# Altium schematic sheet 05_HDMI_O.SchDoc
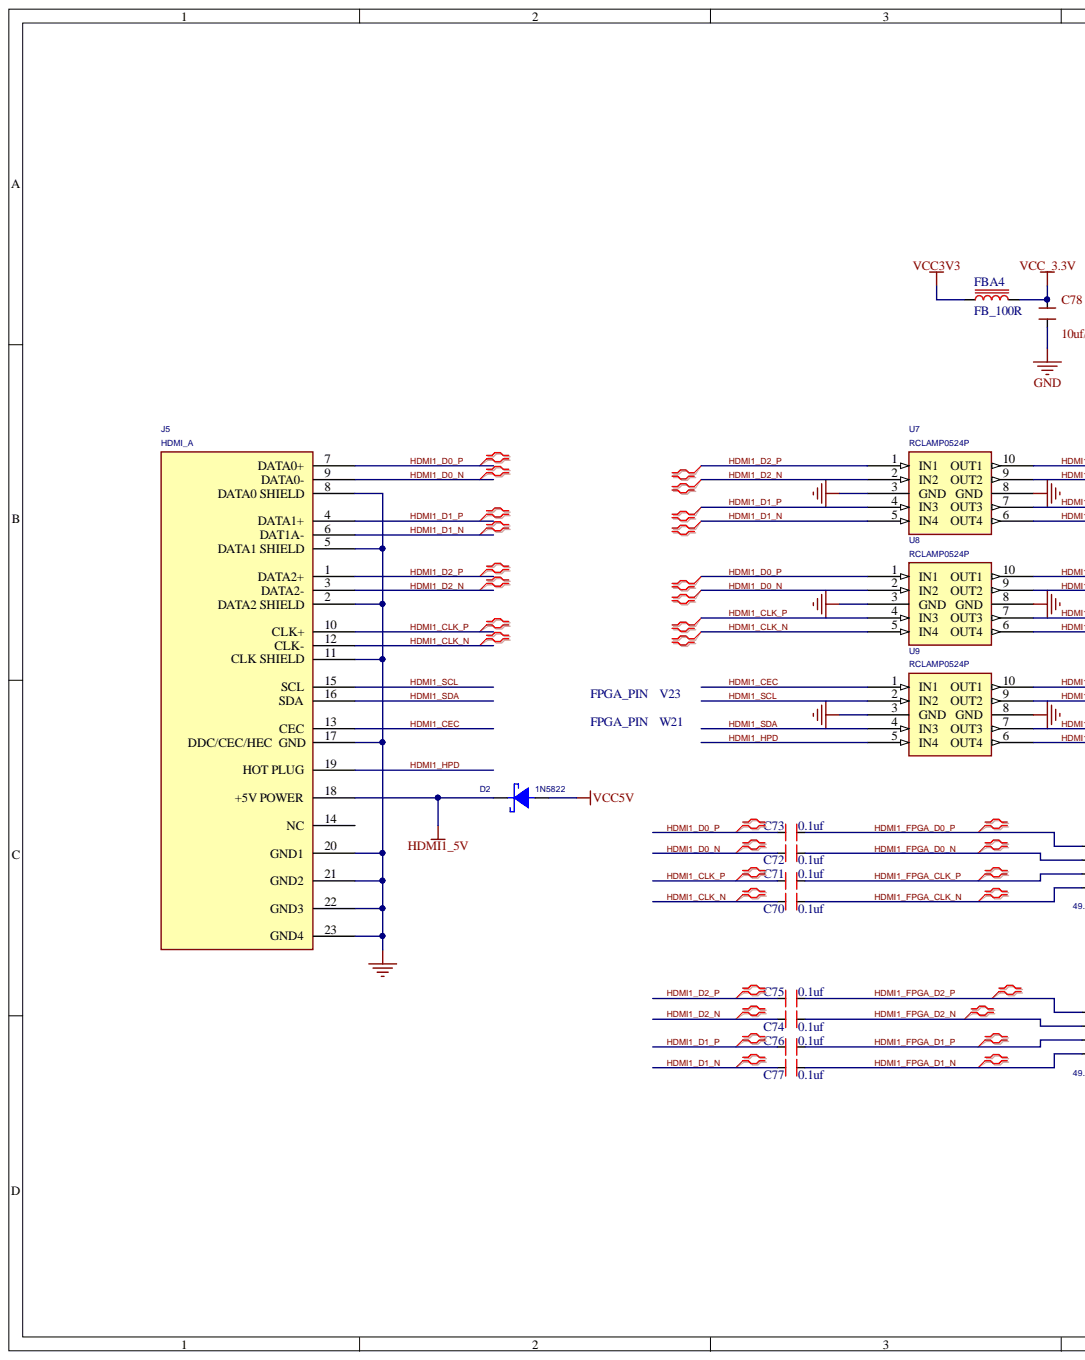
[diagram p0: 05_HDMI_O.SchDoc, left]
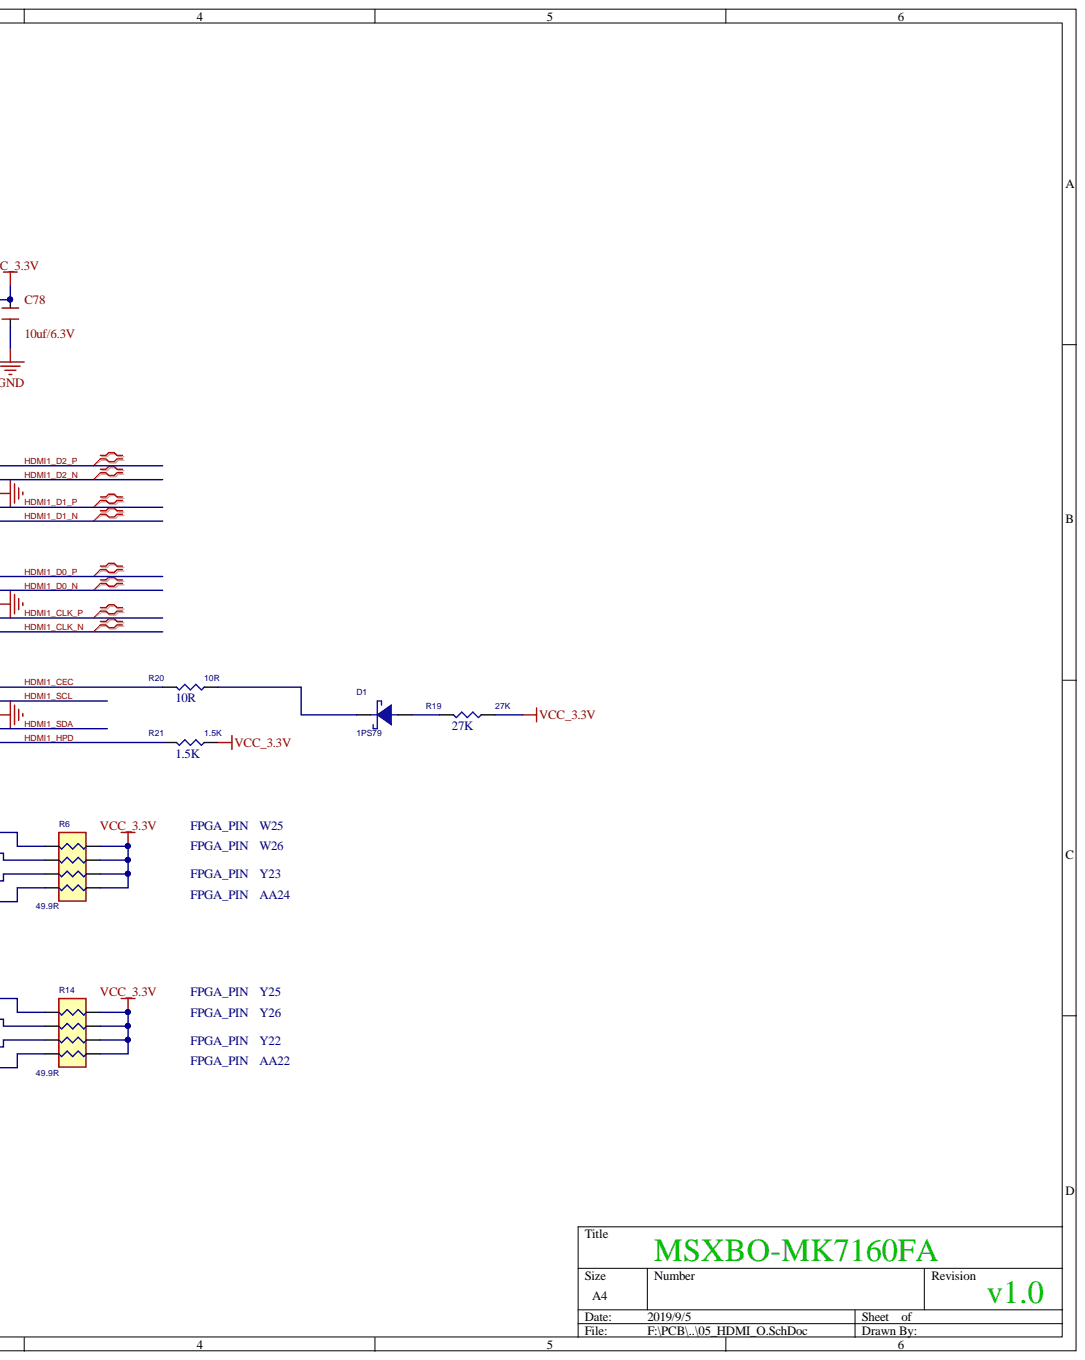
[diagram p1: 05_HDMI_O.SchDoc, right]

## Parts
C70  0.1uf  [C0402]
C71  0.1uf  [C0402]
C72  0.1uf  [C0402]
C73  0.1uf  [C0402]
C74  0.1uf  [C0402]
C75  0.1uf  [C0402]
C76  0.1uf  [C0402]
C77  0.1uf  [C0402]
C78  10uf/6.3V  [CAP0805]
D1  1PS79  [D0603]
D2  1N5822  [SMA_DO_214AC]
FBA4  FB_100R  [CAP0603]
J5  HDMI_A  [HDMI_SMD_A]
R6  49.9R  [RA4_0603]
R14  49.9R  [RA4_0603]
R19  27K  [R0402]
R20  10R  [R0402]
R21  1.5K  [Res0402]
U7  RCLAMP0524P  [SLP2510P8_10]
U8  RCLAMP0524P  [SLP2510P8_10]
U9  RCLAMP0524P  [SLP2510P8_10]

## Nets
GND: C78.2 J5.2(DATA2_SHIELD) J5.5(DATA1_SHIELD) J5.8(DATA0_SHIELD) J5.11(CLK_SHIELD) J5.17(GND) J5.20(GND1) J5.21(GND2) J5.22(GND3) J5.23(GND4) U7.3(GND) U7.8(GND) U8.3(GND) U8.8(GND) U9.3(GND) U9.8(GND)
HDMI1_5V: D2.2 J5.18(+5V_POWER)
VCC3V3: FBA4.1
VCC5V: D2.1
VCC_3.3V: C78.1 FBA4.2 R6.1 R6.2 R6.3 R6.4 R14.1 R14.2 R14.3 R14.4 R19.2 R21.2
HDMI1_CEC: J5.13(CEC) R20.1 U9.1(IN1) U9.10(OUT1)
HDMI1_CLK_N: C70.1 J5.12(CLK-) U8.5(IN4) U8.6(OUT4)
HDMI1_CLK_P: C71.1 J5.10(CLK+) U8.4(IN3) U8.7(OUT3)
HDMI1_D0_N: C72.1 J5.9(DATA0-) U8.2(IN2) U8.9(OUT2)
HDMI1_D0_P: C73.1 J5.7(DATA0+) U8.1(IN1) U8.10(OUT1)
HDMI1_D1_N: C77.1 J5.6(DAT1A-) U7.5(IN4) U7.6(OUT4)
HDMI1_D1_P: C76.1 J5.4(DATA1+) U7.4(IN3) U7.7(OUT3)
HDMI1_D2_N: C74.1 J5.3(DATA2-) U7.2(IN2) U7.9(OUT2)
HDMI1_D2_P: C75.1 J5.1(DATA2+) U7.1(IN1) U7.10(OUT1)
HDMI1_FPGA_CLK_N: C70.2 R6.5
HDMI1_FPGA_CLK_P: C71.2 R6.6
HDMI1_FPGA_D0_N: C72.2 R6.7
HDMI1_FPGA_D0_P: C73.2 R6.8
HDMI1_FPGA_D1_N: C77.2 R14.5
HDMI1_FPGA_D1_P: C76.2 R14.6
HDMI1_FPGA_D2_N: C74.2 R14.7
HDMI1_FPGA_D2_P: C75.2 R14.8
HDMI1_HPD: J5.19(HOT_PLUG) R21.1 U9.5(IN4) U9.6(OUT4)
HDMI1_SCL: J5.15(SCL) U9.2(IN2) U9.9(OUT2)
HDMI1_SDA: J5.16(SDA) U9.4(IN3) U9.7(OUT3)
NetD1_1: D1.1 R19.1
NetD1_2: D1.2 R20.2

Not connected: J5 14(NC)

## Library data of the parts
C70-C77 = C0402: Component Kind: Standard
C78 = CAPACITOR: Generic Capacitor; Value: 4.7uF; Component Kind: Standard; Library Name: Digilent.IntLib
D2 = 1N5817: Component Kind: Standard
FBA4 = Ferrite Bead: Generic Ferrite Bead; Value: 600; Component Kind: Standard; Library Name: MK7X(FUN)20190418.IntLib
R6 R14 = RA-4: Component Kind: Standard
R19-R21 = RES1: DIST: FLAT; MAX_TEMP: RTMAX; POWER: RMAX; PSpiceTemplate: R^@REFDES %1 %2 ?TOLERANCE|R^@REFDES| @VALUE TC=@TC1,@TC2 ?TOLERANCE|\n.model R^@REFDES RES R=1 DEV=@TOLERANCE% TC1=@TC1 TC2=@TC2|; SLOPE: RSMAX; TC1: 0; VOLTAGE: RVMAX; Component Kind: Standard; Simulation: RES1; TC2: 0
  R19: Value: 27K
  R20: Value: 10R
  R21: Value: 1.5K
D1 = 1PS79: Component Kind: Standard
J5 = HDMI_A: Component Kind: Standard
U7-U9 = RCLAMP0524P: Component Kind: Standard
